# Altium schematic sheet NucleoInterface.SchDoc
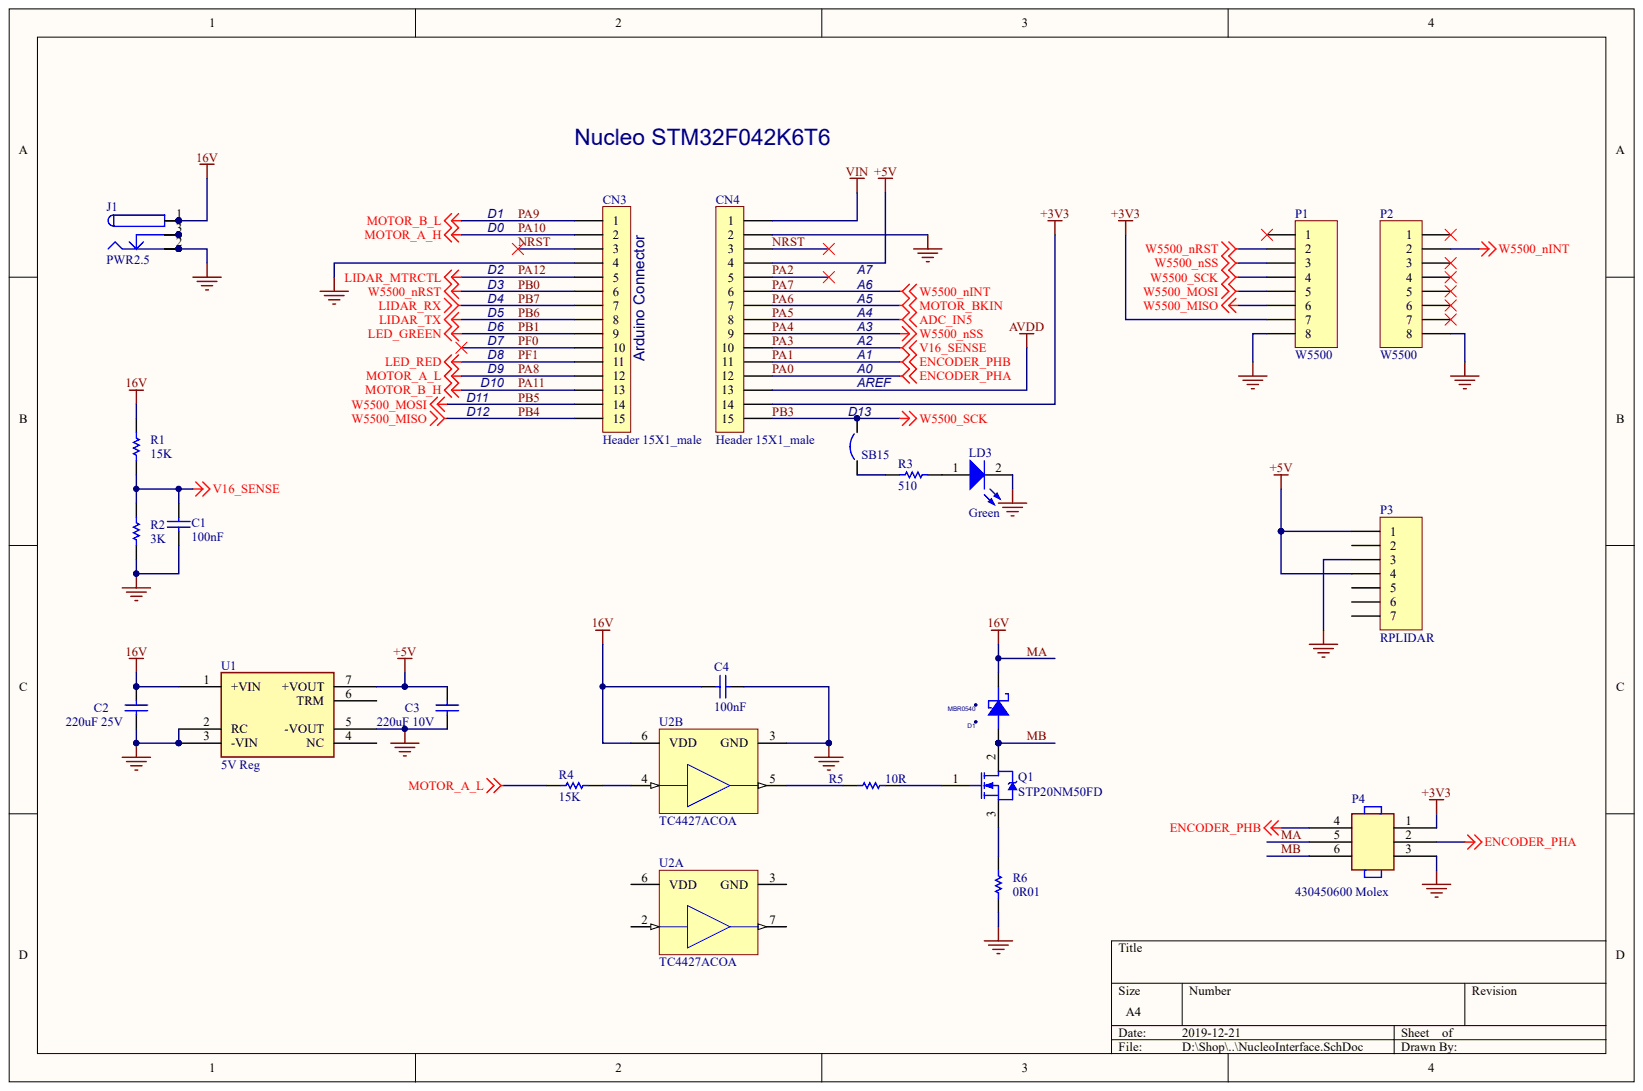
[diagram p0: NucleoInterface.SchDoc]

## Parts
C1  100nF
C2  value 220uF 25V  (hidden comment: C0805)
C3  value 220uF 10V  (hidden comment: C0805)
C4  100nF
CN3  Header 15X1_male
CN4  Header 15X1_male
D1  MBR0540
J1  PWR2.5
LD3  Green
P1  W5500
P2  W5500
P3  RPLIDAR
P4  430450600 Molex
Q1  value STP20NM50FD  MFCTR-PN: STP20NM50FD  (hidden comment: Mosfet Power)
R1  15K
R2  3K
R3  510
R4  15K
R5  10R
R6  0R01
SB15  Circuit Breaker (close)  (value not drawn)
U1  5V Reg
U2  TC4427ACOA  (parts A,B)

## Nets
+3V3: CN4.14 P1.7 P4.1
+5V: C3.1 CN4.4 P3.1 P3.4 U1.7(+VOUT)
AVDD: CN4.13
GND: C1.1 C2.2 C3.2 C4.1 CN3.4 CN4.2 J1.2 J1.2A J1.2B LD3.2 P1.8 P2.8 P3.3 P4.3 R2.1 R6.1 U1.2(RC) U1.3(-VIN) U1.5(-VOUT) U2.3
VIN: CN4.1
MA: C2.1 C4.2 D1.2 J1.1 J1.1A J1.1B P4.5 R1.2 U1.1(+VIN) U2.6
MB: D1.1 P4.6 Q1.2
NRST: CN3.3 CN4.3
PA0: CN4.12 P4.2
PA1: CN4.11 P4.4
PA2: CN4.5
PA3: C1.2 CN4.10 R1.1 R2.2
PA4: CN4.9 P1.3
PA5: CN4.8
PA6: CN4.7
PA7: CN4.6 P2.2
PA8: CN3.12 R4.2
PA9: CN3.1
PA10: CN3.2
PA11: CN3.13
PA12: CN3.5
PB0: CN3.6 P1.2
PB1: CN3.9
PB3: CN4.15 P1.4 SB15.2
PB4: CN3.15 P1.6
PB5: CN3.14 P1.5
PB6: CN3.8
PB7: CN3.7
PF0: CN3.10
PF1: CN3.11
NetJ1_3: J1.3 J1.3A J1.3B
NetLD3_1: LD3.1 R3.1
NetQ1_1: Q1.1 R5.1
NetQ1_3: Q1.3 R6.2
NetR3_2: R3.2 SB15.1
NetR4_1: R4.1 U2.4
NetR5_2: R5.2 U2.5

Not connected: P1 1; P2 1 3 4 5 6 7; P3 2 5 6 7; U1 4(NC) 6(TRM); U2 2 7

## Library data of the parts
C2 C3 = (no symbol name): PartNumber: APXG250ARA221MJA0G; Note: Footprint corresponds to dimensions for bulk cassette packaging. This case size has tightened tolerances.; Published: 17-Jul-2002; LatestRevisionDate: 19-Mar-2004; LatestRevisionNote: Silkscreen size reduced.; DatasheetDocument: Mar-2001; PackageDocument: Mar-2001; PackageReference: 0805; Code_JEITA: 0805; Code_IPC: 2012[0805]; ComponentLink1Description: Manufacturer Link; ComponentLink1URL: http://www.kemet.com/; ComponentLink2Description: Datasheet; ComponentLink2URL: http://www.kemet.com/kemet/web/homepage/kechome.nsf/vapubfilesname/F3102TA.pdf/$file/F3102TA.pdf; Publisher: Altium Limited
Q1 = (no symbol name): D-K PN: 497-6739-5-ND; DK-PN: 497-6739-5-ND
R1 R2 R4-R6 = (no symbol name): Value: 10K
R3 = (no symbol name): Value: 1K; Published: 8-Jun-2000; Revision: July-2002: Re-released for DXP Platform.; Publisher: Altium Limited
SB15 = (no symbol name): Solder: NO; Published: 24-Mar-1999; Revision: July-2002: Re-released for DXP Platform.; Publisher: Altium Limited
U1 = (no symbol name): PartNumber: 430450600 Molex
C1 C4 CN3 CN4 D1 J1 LD3 P1-P4 U2 = (no symbol name)
  C1 C4: Revision: July-2002: Re-released for DXP Platform.; Value: 100nF
  CN3 CN4 P1-P3: LatestRevisionDate: 17-Jul-2002; LatestRevisionNote: Re-released for DXP Platform.
  J1: Published: 17-Dec-2003; DatasheetDocument: 09-May-2002; ComponentLink1Description: Manufacturer Link; ComponentLink1URL: http://domino.kycon.com/internet/Products.html
  LD3: Published: 8-Jun-2000; Revision: July-2002: Re-released for DXP Platform.
  P4: PartNumber: 430450600 Molex
  U2: Published: 29-Jan-2009; LatestRevisionDate: 01-Mar-2010; LatestRevisionNote: Sch Layout changed.; DatasheetVersion: revF, Jan-2006; PackageVersion: revBB, Aug-2009; PackageReference: SOIC-OA8; PackageDescription: 8-Lead Plastic Small Outline (OA) - Narrow, 3.90mm Body [SOIC]; Supplier 1: Digi-Key; Supplier Part Number 1: TC4427ACOA-ND; Supplier 2: Farnell; Supplier Part Number 2: 1467705; Supplier 3: Farnell; Supplier Part Number 3: 3008836; Code_IPC: SOIC127P600-8; ComponentLink1Description: Datasheet; ComponentLink1URL: http://ww1.microchip.com/downloads/en/DeviceDoc/21423F.pdf; ComponentLink2Description: Packaging Specification; ComponentLink2URL: http://www.microchip.com/stellent/groups/techpub_sg/documents/packagingspec/en012702.pdf; ComponentLink3Description: Microchip.com Home; ComponentLink3URL: http://www.microchip.com/
  CN3 CN4 J1 LD3 P1-P3 U2: Publisher: Altium Limited
